# Altium schematic sheet schematic.SchDoc
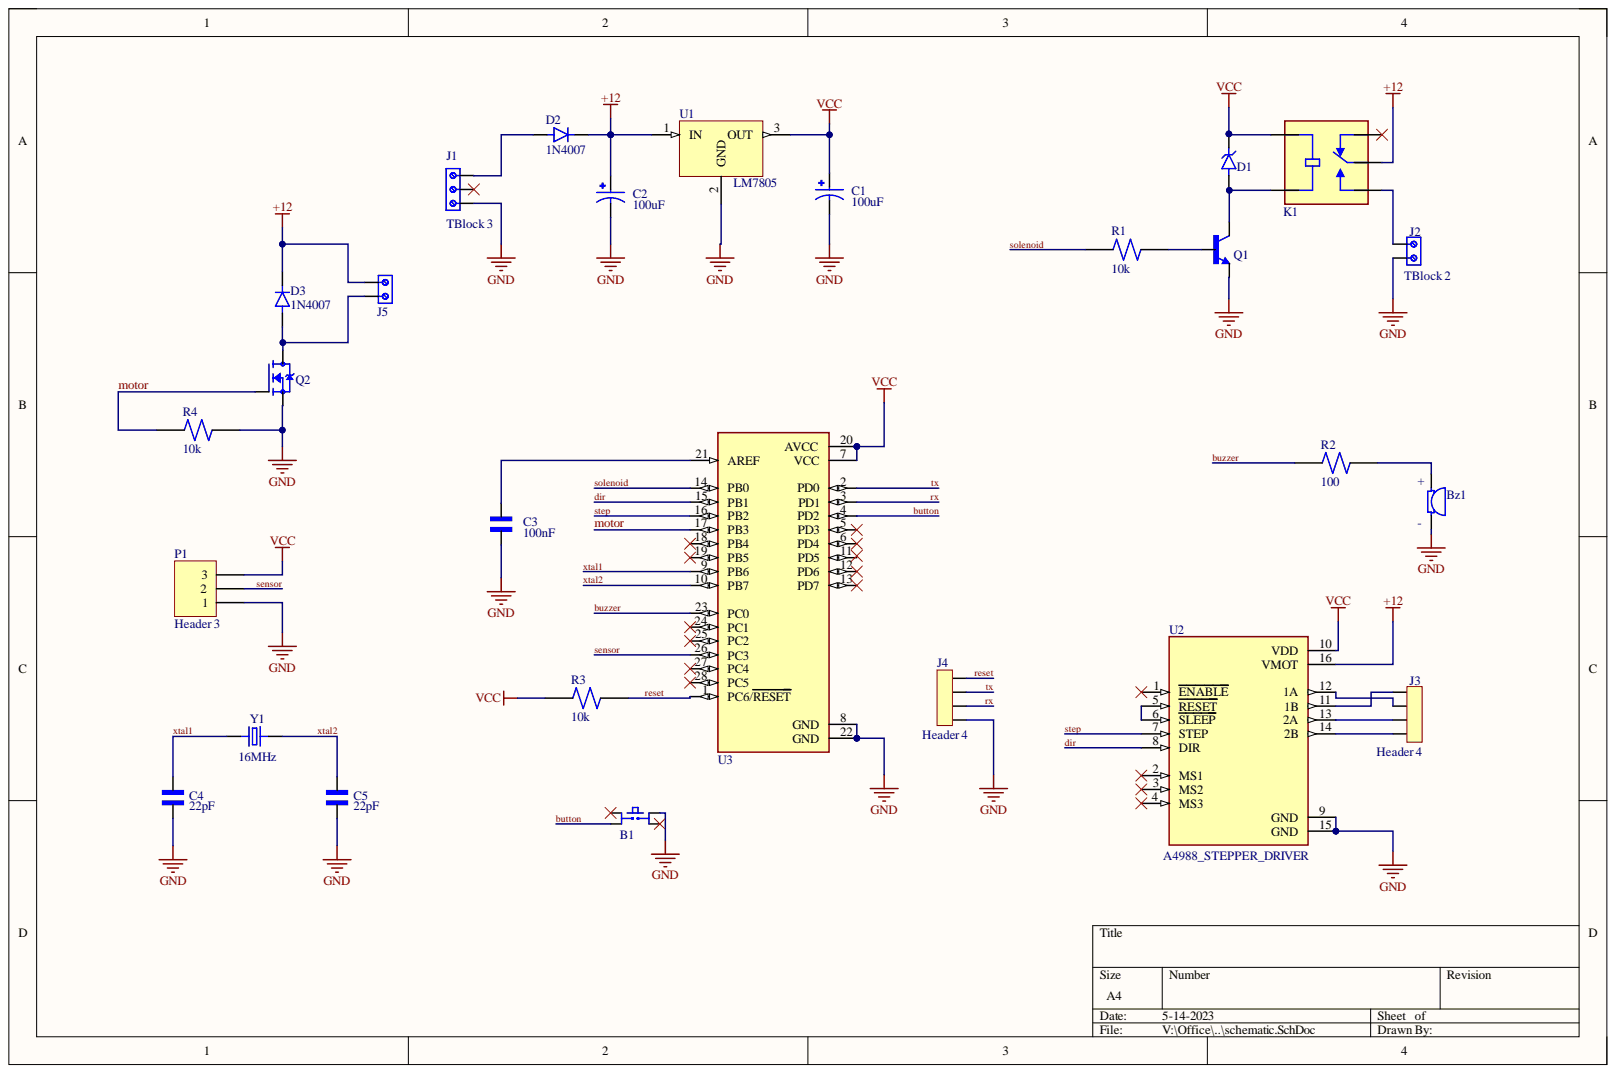
[diagram p0: schematic.SchDoc]

## Parts
B1  Push button  [SW_TS02-66-95-BK-260-LCR-D]  (value not drawn)
Bz1  AI-1223-TWT-12V-R  [XDCR_AI-1223-TWT-12V-R]  (value not drawn)
C1  100uF  [CAPPRD500W50D500H1100]
C2  100uF  [CAPPRD500W50D500H1100]
C3  100nF  [CAPRB500W50L450T300H550]
C4  22pF  [CAPRB500W50L450T300H550]
C5  22pF  [CAPRB500W50L450T300H550]
D1  1N4745A  [DIOAD1060W70L435D230]  (value not drawn)
D2  1N4007  [DIOAD1060W80L520D270]
D3  1N4007  [DIOAD1060W80L520D270]
J1  TBlock 3  [691214110003]
J2  TBlock 2  [691111710002]
J3  Header 4  [SULLINS_PPTC041LFBN-RC]
J4  Header 4  [SULLINS_PPTC041LFBN-RC]
J5  691111710002  [691111710002]  (value not drawn)
K1  JQC-3F-1C-24VDC  [RELAY_JQC-3F-1C-24VDC]  (value not drawn)
P1  Header 3  [HDR1X3]
Q1  BJT Transistor  [TO92250P510H770-3]  (value not drawn)
Q2  N-Channel mosfet  [TO254P1052X465X1989-3]  (value not drawn)
R1  10k  [RESAD1100W55L680D260]
R2  100  [RESAD1100W55L680D260]
R3  10k  [RESAD1100W55L680D260]
R4  10k  [RESAD1100W55L680D260]
U1  LM7805  [TO254P1054X470X1955-3]
U2  A4988_STEPPER_DRIVER  [MODULE_A4988_STEPPER_MOTOR_DRIVER_CARRIER]
U3  ATMEGA328P-PU  [DIP794W46P254L2967H457Q28B]  (value not drawn)
Y1  16MHz  [XTAL_ECS-160-20-4X]

## Nets
+12: C2.P D2.C D3.C J5.1 K1.COM U1.1 U2.16(VMOT)
GND: B1.3 Bz1.N C1.N C2.N C3.1 C4.2 C5.2 J1.1 J2.2 J4.4 P1.1 Q1.3 Q2.3 R4.2 U1.2 U2.9(GND) U2.15(GND) U3.8(GND) U3.22(GND)
VCC: C1.P D1.C K1.COIL1 P1.3 R3.1 U1.3 U2.10(VDD) U3.7(VCC) U3.20(AVCC)
button: B1.2 U3.4(PD2)
buzzer: R2.1 U3.23(PC0)
dir: U2.8(DIR) U3.15(PB1)
motor: Q2.1 R4.1 U3.17(PB3)
reset: J4.1 R3.2 U3.1(PC6/RESET)
rx: J4.3 U3.3(PD1)
sensor: P1.2 U3.26(PC3)
solenoid: R1.1 U3.14(PB0)
step: U2.7(STEP) U3.16(PB2)
tx: J4.2 U3.2(PD0)
xtal1: C4.1 U3.9(PB6) Y1.1
xtal2: C5.1 U3.10(PB7) Y1.2
NetBz1_P: Bz1.P R2.2
NetC3_2: C3.2 U3.21(AREF)
NetD1_A: D1.A K1.COIL2 Q1.1
NetD2_A: D2.A J1.3
NetD3_A: D3.A J5.2 Q2.2
NetJ2_1: J2.1 K1.NO
NetJ3_1: J3.1 U2.14(2B)
NetJ3_2: J3.2 U2.13(2A)
NetJ3_3: J3.3 U2.12(1A)
NetJ3_4: J3.4 U2.11(1B)
NetQ1_2: Q1.2 R1.2
NetU2_5: U2.5(RESET) U2.6(SLEEP)

Not connected: B1 1 4; J1 2; K1 NC; U2 1(ENABLE) 2(MS1) 3(MS2) 4(MS3); U3 5(PD3) 6(PD4) 11(PD5) 12(PD6) 13(PD7) 18(PB4) 19(PB5) 24(PC1) 25(PC2) 27(PC4) 28(PC5)

## Library data of the parts
Bz1 = Buzzer: ELECTRO-MECHANICAL AUDIO INDICATOR; MF: PUI Audio,; Availability: In Stock; Component Kind: Standard; MP: AI-1223-TWT-12V-R; Package: 2225 PUI Audio; Price: None; Purchase-URL: https://pricing.snapeda.com/search/part/AI-1223-TWT-12V-R/?ref=eda; Library Name: Installed library.IntLib
C1 C2 = Electrolytic capacitor: FS-A; MF: {'manufacturer': u'Panasonic'}; MP: EEUFS0J221B; Availability: Unavailable; Component Kind: Standard; Package: None; Price: None; Library Name: Installed library.IntLib
C3-C5 = Ceramic capacitor: 2200 pF Â±10% 250V Ceramic Capacitor X7R Radial; MF: TDK; MP: FG24X7R2E222KNT06; Check_prices: https://www.snapeda.com/parts/FG24X7R2E222KNT06/TDK/view-part/?ref=eda; Component Kind: Standard; Digi-Key_Part_Number: 445-173386-1-ND; Package: Radial TDK; Purchase-URL: https://pricing.snapeda.com/search/part/FG24X7R2E222KNT06/?ref=eda; SnapEDA_Link: https://www.snapeda.com/parts/FG24X7R2E222KNT06/TDK/view-part/?ref=snap; Library Name: Installed library.IntLib
D1 = Zenner diode: Zener Diode 16V 1W Â±5% Through Hole DO-204AL (DO-41); MF: ON Semiconductor; Availability: In Stock; Check_prices: https://www.snapeda.com/parts/1N4745A/Onsemi/view-part/?ref=eda; Component Kind: Standard; MP: 1N4745A; Package: DO-41-2 ON Semiconductor; Price: None; Purchase-URL: https://pricing.snapeda.com/search/part/1N4745A/?ref=eda; SnapEDA_Link: https://www.snapeda.com/parts/1N4745A/Onsemi/view-part/?ref=snap; Library Name: Installed library.IntLib
D2 D3 = 1N4007: Diode Switching 1KV 1A 2-Pin DO-41; Availability: Unavailable; Component Kind: Standard; Library Name: Components.IntLib; MP: 1N4007; Package: None; Price: None
J1 = Terminal blocks - 3Pins (Small): 3 Position Wire to Board Terminal Block Horizontal with Board 0.138 (3.50mm) Through Hole; MF: Wurth Elektronik; Availability: In Stock; Check_prices: https://www.snapeda.com/parts/691214110003/Wurth+Elektronik/view-part/?ref=eda; Component Kind: Standard; MP: 691214110003; Package: None; Price: None; Purchase-URL: https://pricing.snapeda.com/search/part/691214110003/?ref=eda; SnapEDA_Link: https://www.snapeda.com/parts/691214110003/Wurth+Elektronik/view-part/?ref=snap; Library Name: Installed library.IntLib
J2 J5 = Terminal Block - 2Pins: 2 Position Wire to Board Terminal Block Horizontal with Board 0.197 _5.00mm_ Through Hole; MF: Wurth Electronics; Availability: Unavailable; Component Kind: Standard; MP: 691111710002; Package: None; Price: None; SnapEDA_Link: https://www.snapeda.com/parts/691111710002/Wurth%20Electronics%20Inc./view-part/1195092/?ref=snap; Library Name: Installed library.IntLib
J3 J4 = Female header - 4Pins: 4 Position Header Connector Through Hole; MF: Sullins Connector; MP: PPTC041LFBN-RC; Availability: Warning; Check_prices: https://www.snapeda.com/parts/PPTC041LFBN-RC/Sullins%20Connector%20Solutions/view-part/285917/?ref=eda; Component Kind: Standard; Package: None; Price: None; Purchase-URL: https://pricing.snapeda.com/search/part/PPTC041LFBN-RC/?ref=eda; SnapEDA_Link: https://www.snapeda.com/parts/PPTC041LFBN-RC/Sullins%20Connector%20Solutions/view-part/285917/?ref=snap; Library Name: Installed library.IntLib
K1 = Relay (Standard): Miniature PCB Relay 24VDC, 10A 250VAC, 15A 125VAC, 10A 250VAC; Availability: Unavailable; Component Kind: Standard; MP: JQC-3F-1C-24VDC; Package: None; Price: None; Library Name: Installed library.IntLib
P1 = Header 3: Header, 3-Pin; Component Kind: Standard; LatestRevisionDate: 17-Jul-2002; LatestRevisionNote: Re-released for DXP Platform.; Library Name: Miscellaneous Connectors.IntLib; Signal Integrity: Connector; Publisher: Altium Limited
Q1 = BC547B: <a href="https://pricing.snapeda.com/parts/BC337-16%20A1/Taiwan%20Semiconductor/view-part?ref=eda">Check availability</a> <a href="https://pricing.snapeda.com/parts/BC337-16%20A1/Taiwan%20Semiconductor/view-part?ref=eda">Check availability</a>; Availability: Unavailable; Component Kind: Standard; MP: BC547B; Package: None; Price: None; Library Name: Installed library.IntLib
Q2 = IRF540: N-Channel 100V 22A (Tc) 85W (Tc) Through Hole TO-220AB; Availability: Unavailable; Component Kind: Standard; MP: IRF540; Package: None; Price: None; Library Name: Installed library.IntLib
R1-R4 = Resistor (0.25W): (MFR-25FBF52-10K) 10,2 kOhm bei 1% 0,25W, 1/4W Durchbohrlochwiderstand Axial Metal Film; MF: Yageo; Component Kind: Standard; Digi-Key_Part_Number: 10.2KXBK-ND; MP: MFR-25FBF52-10K; Package: Axial Yageo; Purchase-URL: https://pricing.snapeda.com/search/part/MFR-25FBF52-10K/?ref=eda; SnapEDA_Link: https://www.snapeda.com/parts/MFR-25FBF52-10K/Yageo/view-part/424333/?ref=snap; Library Name: Installed library.IntLib
U1 = LM7805CT/NOPB: Availability: Unavailable; Component Kind: Standard; Library Name: Voltage regulator.IntLib; MP: LM7805CT/NOPB; Package: None; Price: None
U2 = A4988_STEPPER_MOTOR_DRIVER_CARRIER: Stepper motor controler; IC: A4988; 1A; Uin mot: 8Ã·35V; Availability: Unavailable; Component Kind: Standard; Library Name: Modules.IntLib; MP: A4988_STEPPER_MOTOR_DRIVER_CARRIER; Package: None; Price: None
U3 = ATMEGA328P-PU: ATmega Series 20 MHz 32 KB Flash 2 KB SRAM 8-Bit Microcontroller - DIP-28; Availability: Unavailable; Component Kind: Standard; MP: ATMEGA328P-PU; Package: None; Price: None; Library Name: Installed library.IntLib
Y1 = Crystal oscilator: CRYSTAL T/H; MF: {'manufacturer': u'ECS Inc.'}; MP: ECS-160-S-4; Availability: Unavailable; Component Kind: Standard; Package: None; Price: None; Library Name: Installed library.IntLib
B1 = Push button: Component Kind: Standard; Library Name: Installed library.IntLib
